# Altium schematic sheet actuator2.SchDoc
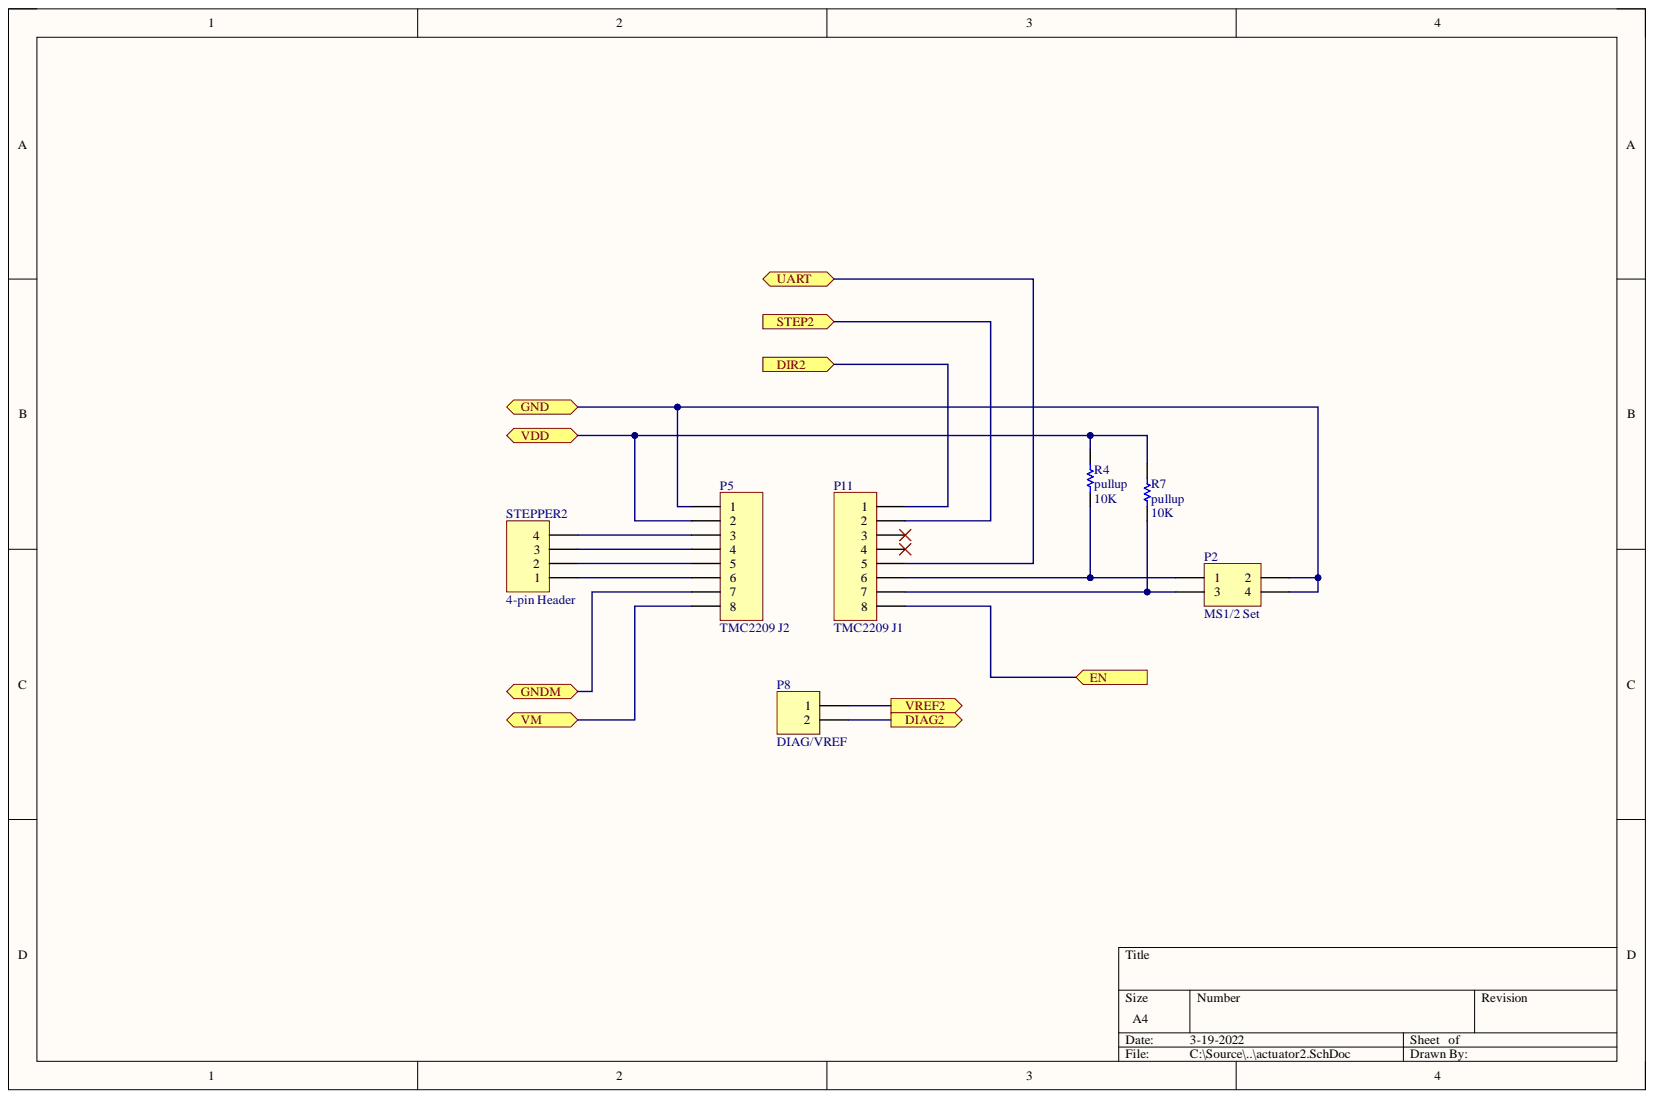
[diagram p0: actuator2.SchDoc]

## Parts
P2  MS1/2 Set  [HDR2X2]
P5  TMC2209 J2  [HDR1X8]
P8  DIAG/VREF  [HDR1X2]
P11  TMC2209 J1  [HDR1X8]
R4  pullup  value 10K  [AXIAL-0.3]
R7  pullup  value 10K  [AXIAL-0.3]
STEPPER2  4-pin Header  [HDR1X4]

## Ports
DIAG2, DIR2, EN, GND, GNDM, STEP2, UART, VDD, VM, VREF2

## Nets
DIAG2: P8.2 ; port DIAG2
DIR2: P11.1 ; port DIR2
EN: P11.8 ; port EN
GND: P2.2 P2.4 P5.1 ; port GND
GNDM: P5.7 ; port GNDM
STEP2: P11.2 ; port STEP2
UART: P11.5 ; port UART
VDD: P5.2 R4.2 R7.2 ; port VDD
VM: P5.8 ; port VM
VREF2: P8.1 ; port VREF2
NetP2_1: P2.1 P11.6 R4.1
NetP2_3: P2.3 P11.7 R7.1
NetP5_3: P5.3 STEPPER2.4
NetP5_4: P5.4 STEPPER2.3
NetP5_5: P5.5 STEPPER2.2
NetP5_6: P5.6 STEPPER2.1

Not connected: P11 3 4

## Library data of the parts
P2 = Header 2X2: Header, 2-Pin, Dual row; Component Kind: Standard; LatestRevisionDate: 17-Jul-2002; LatestRevisionNote: Re-released for DXP Platform.; Library Name: Miscellaneous Connectors.IntLib; Signal Integrity: Connector; Publisher: Altium Limited
P5 P11 = Header 8: Header, 8-Pin; Component Kind: Standard; LatestRevisionDate: 17-Jul-2002; LatestRevisionNote: Re-released for DXP Platform.; Library Name: miscellaneous_connectors.IntLIb; Signal Integrity: Connector; Publisher: Altium Limited
P8 = Header 2: Header, 2-Pin; Component Kind: Standard; LatestRevisionDate: 17-Jul-2002; LatestRevisionNote: Re-released for DXP Platform.; Library Name: miscellaneous_connectors.IntLIb; Signal Integrity: Connector; Publisher: Altium Limited
R4 R7 = Res1: Resistor; Component Kind: Standard; LatestRevisionDate: 17-Jul-2002; LatestRevisionNote: Re-released for DXP Platform.; Library Name: Miscellaneous Devices.IntLib; PackageDescription: Axial Device, Thru-Hole; 2 Leads; 0.3 in Pin Spacing; PackageReference: AXIAL-0.3; Published: 8-Jun-2000; Signal Integrity: Res; Simulation: RESISTOR; Publisher: Altium Limited
STEPPER2 = Header 4: Header, 4-Pin; Component Kind: Standard; LatestRevisionDate: 17-Jul-2002; LatestRevisionNote: Re-released for DXP Platform.; Library Name: Miscellaneous Connectors.IntLib; Signal Integrity: Connector; Publisher: Altium Limited
